# Altium schematic sheet [09] HDMI.SchDoc("[09] HDMI")
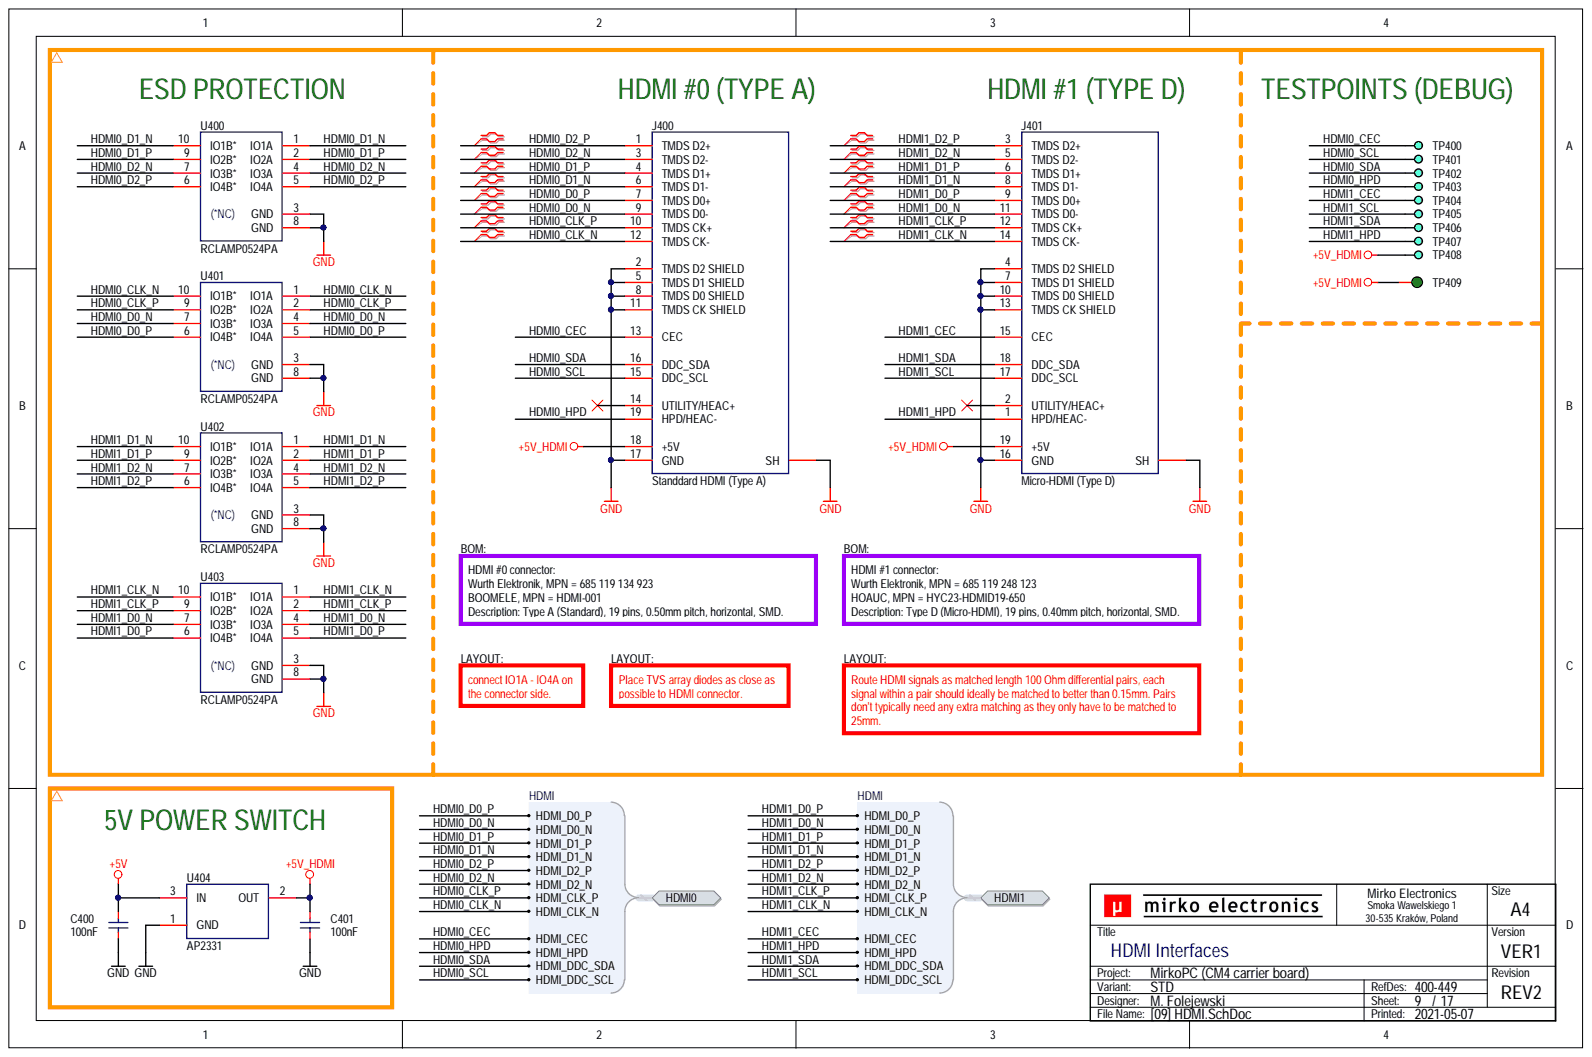
[diagram p0: [09] HDMI.SchDoc]

## Parts
C400  100nF  [CAP_0603_R]
C401  100nF  [CAP_0603_R]
J400  Standdard HDMI (Type A)  Component Subtype: HDMI (Type A)  [CON_HDMI]
J401  Micro-HDMI (Type D)  Component Subtype: HDMI (Type D)
TP400  DEBUG  [PCB_TP_DBG_50]  (value not drawn)  (no BOM)
TP401  DEBUG  [PCB_TP_DBG_50]  (value not drawn)  (no BOM)
TP402  DEBUG  [PCB_TP_DBG_50]  (value not drawn)  (no BOM)
TP403  DEBUG  [PCB_TP_DBG_50]  (value not drawn)  (no BOM)
TP404  DEBUG  [PCB_TP_DBG_50]  (value not drawn)  (no BOM)
TP405  DEBUG  [PCB_TP_DBG_50]  (value not drawn)  (no BOM)
TP406  DEBUG  [PCB_TP_DBG_50]  (value not drawn)  (no BOM)
TP407  DEBUG  [PCB_TP_DBG_50]  (value not drawn)  (no BOM)
TP408  DEBUG  [PCB_TP_DBG_50]  (value not drawn)  (no BOM)
TP409  ICT  [PCB_TP_ICT_100]  (value not drawn)  (no BOM)
U400  RCLAMP0524PA  [ICC_SLP2510P8_250X100X60_P50_R]
U401  RCLAMP0524PA  [ICC_SLP2510P8_250X100X60_P50_R]
U402  RCLAMP0524PA  [ICC_SLP2510P8_250X100X60_P50_R]
U403  RCLAMP0524PA  [ICC_SLP2510P8_250X100X60_P50_R]
U404  AP2331  [PKG_SOT23-3L_SC59_P190_R]

## Ports
HDMI0, HDMI0.HDMI_CEC, HDMI0.HDMI_CLK_N, HDMI0.HDMI_CLK_P, HDMI0.HDMI_D0_N, HDMI0.HDMI_D0_P, HDMI0.HDMI_D1_N, HDMI0.HDMI_D1_P, HDMI0.HDMI_D2_N, HDMI0.HDMI_D2_P, HDMI0.HDMI_DDC_SCL, HDMI0.HDMI_DDC_SDA, HDMI0.HDMI_HPD, HDMI1, HDMI1.HDMI_CEC, HDMI1.HDMI_CLK_N, HDMI1.HDMI_CLK_P, HDMI1.HDMI_D0_N, HDMI1.HDMI_D0_P, HDMI1.HDMI_D1_N, HDMI1.HDMI_D1_P, HDMI1.HDMI_D2_N, HDMI1.HDMI_D2_P, HDMI1.HDMI_DDC_SCL, HDMI1.HDMI_DDC_SDA, HDMI1.HDMI_HPD

## Nets
+5V: C400.2 U404.3
+5V_HDMI: C401.2 J400.18(+5V) J401.19(+5V) TP408.1 TP409.1 U404.2
GND: C400.1 C401.1 J400.2(TMDS_D2_SHIELD) J400.5(TMDS_D1_SHIELD) J400.8(TMDS_D0_SHIELD) J400.11(TMDS_CK_SHIELD) J400.17(GND) J400.SH J401.4(TMDS_D2_SHIELD) J401.7(TMDS_D1_SHIELD) J401.10(TMDS_D0_SHIELD) J401.13(TMDS_CK_SHIELD) J401.16(GND) J401.SH U400.3(GND) U400.8(GND) U401.3(GND) U401.8(GND) U402.3(GND) U402.8(GND) U403.3(GND) U403.8(GND) U404.1
HDMI0_CEC: J400.13(CEC) TP400.1 ; port HDMI0
HDMI0_CLK_N: J400.12(TMDS_CK-) U401.1(IO1A) U401.10(IO1B*) ; port HDMI0
HDMI0_CLK_P: J400.10(TMDS_CK+) U401.2(IO2A) U401.9(IO2B*) ; port HDMI0
HDMI0_D0_N: J400.9(TMDS_D0-) U401.4(IO3A) U401.7(IO3B*) ; port HDMI0
HDMI0_D0_P: J400.7(TMDS_D0+) U401.5(IO4A) U401.6(IO4B*) ; port HDMI0
HDMI0_D1_N: J400.6(TMDS_D1-) U400.1(IO1A) U400.10(IO1B*) ; port HDMI0
HDMI0_D1_P: J400.4(TMDS_D1+) U400.2(IO2A) U400.9(IO2B*) ; port HDMI0
HDMI0_D2_N: J400.3(TMDS_D2-) U400.4(IO3A) U400.7(IO3B*) ; port HDMI0
HDMI0_D2_P: J400.1(TMDS_D2+) U400.5(IO4A) U400.6(IO4B*) ; port HDMI0
HDMI0_HPD: J400.19(HPD/HEAC-) TP403.1 ; port HDMI0
HDMI0_SCL: J400.15(DDC_SCL) TP401.1 ; port HDMI0
HDMI0_SDA: J400.16(DDC_SDA) TP402.1 ; port HDMI0
HDMI1_CEC: J401.15(CEC) TP404.1 ; port HDMI1
HDMI1_CLK_N: J401.14(TMDS_CK-) U403.1(IO1A) U403.10(IO1B*) ; port HDMI1
HDMI1_CLK_P: J401.12(TMDS_CK+) U403.2(IO2A) U403.9(IO2B*) ; port HDMI1
HDMI1_D0_N: J401.11(TMDS_D0-) U403.4(IO3A) U403.7(IO3B*) ; port HDMI1
HDMI1_D0_P: J401.9(TMDS_D0+) U403.5(IO4A) U403.6(IO4B*) ; port HDMI1
HDMI1_D1_N: J401.8(TMDS_D1-) U402.1(IO1A) U402.10(IO1B*) ; port HDMI1
HDMI1_D1_P: J401.6(TMDS_D1+) U402.2(IO2A) U402.9(IO2B*) ; port HDMI1
HDMI1_D2_N: J401.5(TMDS_D2-) U402.4(IO3A) U402.7(IO3B*) ; port HDMI1
HDMI1_D2_P: J401.3(TMDS_D2+) U402.5(IO4A) U402.6(IO4B*) ; port HDMI1
HDMI1_HPD: J401.1(HPD/HEAC-) TP407.1 ; port HDMI1
HDMI1_SCL: J401.17(DDC_SCL) TP405.1 ; port HDMI1
HDMI1_SDA: J401.18(DDC_SDA) TP406.1 ; port HDMI1

Not connected: J400 14(UTILITY/HEAC+); J401 2(UTILITY/HEAC+)

## Library data of the parts
C400 C401 = CAP-0008: Capacitor; MLCC; 100nF; TBD; TBD; TBD; TBD; SMD; 0603 (1608);; Database Table Name: CAPACITOR$; Value: 100nF; Supplier: ?; Package (Case): 0603 (1608); MPN: TBD; Mounting Type: SMD; Manufacturer: TBD; Component Type: Capacitor; Component Subtype: MLCC; Component Kind: Standard; Library Name: MirFol_db_local.DbLib
J400 = CON_HDMI_1.4+_A2: Connector; HDMI v1.4+;; MPN: HDMI-001; Manufacturer: BOOMELE; Package (Case): SMD; Value: Standdard HDMI (Type A); Supplier: ?; Mounting Type: SMD; Component Type: Connector; Component Kind: Standard; Library Name: MirFol_lib.SchLib
J401 = CON_HDMI_1.4+_MICRO_TYPE_D_A2: Connector; HDMI v1.4+; Type D (Micro-HDMI);; MPN: HYC23-HDMID19-650; Manufacturer: HOAUC; Package (Case): SMD; Value: Micro-HDMI (Type D); Supplier: ?; Mounting Type: SMD; Component Type: Connector; Component Kind: Standard; Library Name: MirFol_lib.SchLib
TP400-TP408 = PCB_TP_DBG: PCB Marking; Test Point (Debug);; Value: DEBUG; Supplier: ?; Mounting Type: -; Component Type: Testpoint; Component Subtype: -; Library Name: MirFol_lib.SchLib
TP409 = PCB_TP_ICT: Test Point (ICT); Specification: Testpoint (for ICT), SMD pad; Value: ICT; MPN: -; Mounting Type: Non component; Manufacturer: -; Component Type: Testpoint (for ICT); Library Name: MirFol_lib.SchLib
U400-U403 = ICC-0005: IC; TVS Arrays; ESD Protection; 4-Channel; 17kV Air; 12kV Contact; 0.3pF Capitance; TBD; SMD; TBD;; MPN: RCLAMP0524PATCT; Manufacturer: Semtech Corporation; Database Table Name: IC (MISC)$; Value: RCLAMP0524PA; Supplier: ?; Package (Case): TBD; Mounting Type: SMD; Component Type: IC; Component Subtype: TVS Arrays; Component Kind: Standard; Library Name: MirFol_db_local.DbLib
U404 = ICC-0008: IC; Power Switcher; TBD TBD; SMD; SC59;; MPN: AP2331W-7; Manufacturer: Diodes Incorporated; Database Table Name: IC (MISC)$; Value: AP2331; Supplier: ?; Package (Case): SC59; Mounting Type: SMD; Component Type: IC; Component Subtype: Power Switcher; Component Kind: Standard; Library Name: MirFol_db_local.DbLib
